# Altium schematic sheet Power-Electronics.SchDoc(Power-Electronics)
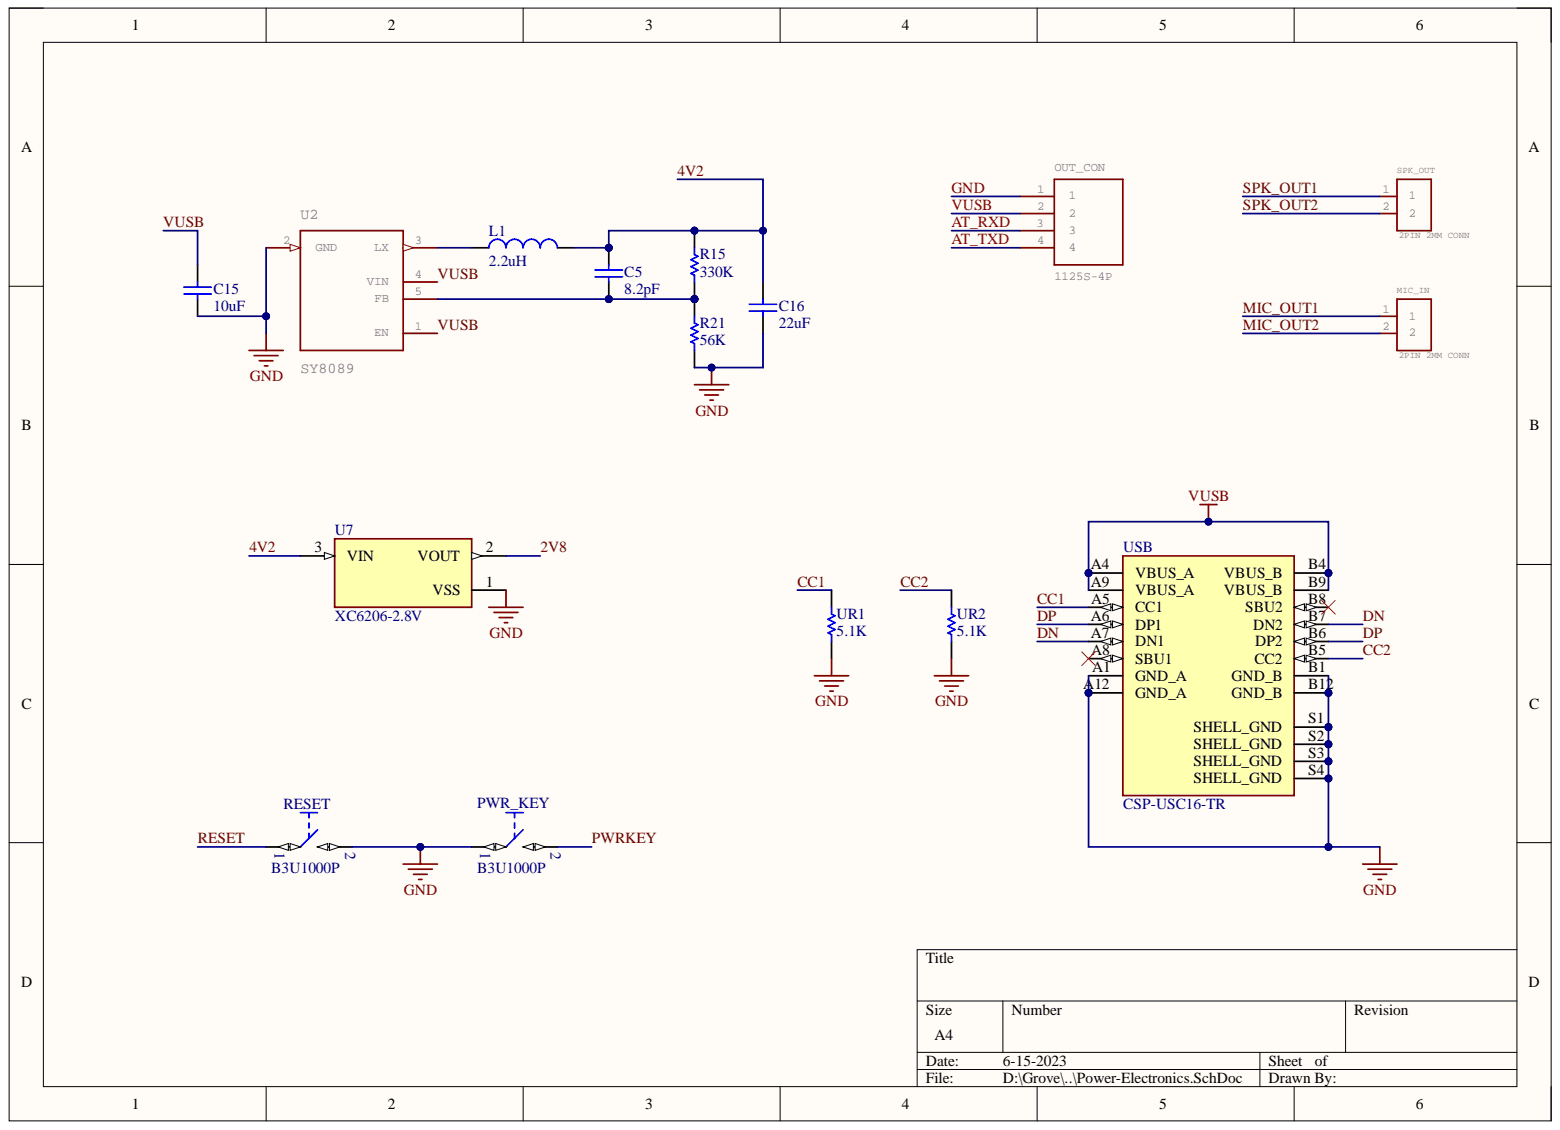
[diagram p0: Power-Electronics.SchDoc]

## Parts
C5  8.2pF  [CAPC3413X12N]
C15  10uF  [CAP805]
C16  22uF  [CAP805]
L1  2.2uH  [IND1206]
MIC_IN  2PIN 2MM CONN  [TE_292253-2]
OUT_CON  1125S-4P  [SEEED_110990030]
PWR_KEY  B3U1000P  [B3U-1000P]
R15  330K  [RESC3413X04N]
R21  56K  [RESC3413X04N]
RESET  B3U1000P  [B3U-1000P]
SPK_OUT  2PIN 2MM CONN  [TE_292253-2]
U2  SY8089  [SOT95P280X145-5N]
U7  XC6206-2.8V  [SOT95P280X130-3N]
UR1  5.1K  [RES0603]
UR2  5.1K  [RES0603]
USB  CSP-USC16-TR  [VALCON_CSP-USC16-TR]

## Nets
2V8: U7.2
4V2: C5.2 C16.2 L1.2 R15.2 U7.3
AT_RXD: OUT_CON.3
AT_TXD: OUT_CON.4
CC1: UR1.2 USB.A5(CC1)
CC2: UR2.2 USB.B5(CC2)
DN: USB.A7(DN1) USB.B7(DN2)
DP: USB.A6(DP1) USB.B6(DP2)
GND: C15.1 C16.1 OUT_CON.1 PWR_KEY.1 R21.1 RESET.2 U2.2(GND) U7.1 UR1.1 UR2.1 USB.A1(GND_A) USB.A12(GND_A) USB.B1(GND_B) USB.B12(GND_B) USB.S1(SHELL_GND) USB.S2(SHELL_GND) USB.S3(SHELL_GND) USB.S4(SHELL_GND)
MIC_OUT1: MIC_IN.1
MIC_OUT2: MIC_IN.2
PWRKEY: PWR_KEY.2
RESET: RESET.1
SPK_OUT1: SPK_OUT.1
SPK_OUT2: SPK_OUT.2
VUSB: C15.2 OUT_CON.2 U2.1(EN) U2.4(VIN) USB.A4(VBUS_A) USB.A9(VBUS_A) USB.B4(VBUS_B) USB.B9(VBUS_B)
NetC5_1: C5.1 R15.1 R21.2 U2.5(FB)
NetL1_1: L1.1 U2.3(LX)

Not connected: USB A8(SBU1) B8(SBU2)

## Library data of the parts
C5 = CAP SMD 0805: CAP 0805; Designer: Abbas Basereh; Component Kind: Standard; Data: 16-12-2016; Desc.: CAP SMD 0805; Library Name: Altium.IntLib
C15 C16 = CAP SMD PACKAGE: TOTAL CAPACITOR SMD PACK; Desc.: CAP SMD TOTAL PACKAGES; Designer: Abbas Basereh; VALUE: 100nF; Component Kind: Standard; Data: 2017-3-10; Library Name: Altium.IntLib; Signal Integrity: CAP SMD
L1 = INDUCTOR SMD PACKAGE: TOTAL INDUCTOR SMD PACK; Desc.: INDUCTOR  SMD TOTAL PACKAGES; Designer: Abbas Basereh; VALUE: 1u; Component Kind: Standard; Data: 2017-3-10; Library Name: Altium.IntLib; Signal Integrity: IND SMD
MIC_IN SPK_OUT = 292253-2: GateName_1: G$1; MF: TE Connectivity; Availability: In Stock; Component Kind: Standard; DeviceSetName: 292253-2; EU_RoHS_Compliance: Compliant; Library Name: 292253-2.Library.IntLib; LibraryName: 292253-2; MP: 292253-2; Package: TE_292253-2; Price: None; Purchase-URL: https://pricing.snapeda.com/search/part/292253-2/?ref=eda; SymbolName_1: 292253-2
OUT_CON = 110990030: GateName_1: G$1; MF: Seeed Technology Co., Ltd; Availability: In Stock; Component Kind: Standard; DeviceSetName: 110990030; Library Name: 110990030.Library.IntLib; LibraryName: 110990030; MP: 110990030; Package: SEEED_110990030; Price: None; Purchase-URL: https://pricing.snapeda.com/search/part/110990030/?ref=eda; SymbolName_1: 110990030
PWR_KEY RESET = B3U1000P: Switch Tactile N.O. SPST Round Button Gull Wing 0.05A 12VDC 1.5N SMD T/R; MF: Omron; Availability: In Stock; Check_prices: https://www.snapeda.com/parts/B3U1000P/Omron/view-part/?ref=eda; Component Kind: Standard; Library Name: B3U1000P.IntLib; MP: B3U1000P; Package: None; Price: None; SnapEDA_Link: https://www.snapeda.com/parts/B3U1000P/Omron/view-part/?ref=snap
R15 R21 = RES 0805 0.125W: RESISTOR 0805; Desc.: RES SMD 0805; Designer: Abbas Basereh; Component Kind: Standard; Data: 16-12-2016; Library Name: Altium.IntLib
U2 = TLV70433DBVR: GateName_1: G$1; MF: Texas Instruments; Availability: In Stock; Component Kind: Standard; DeviceSetName: TLV70433DBVR; Library Name: TLV70433DBVR.Library.IntLib; LibraryName: TLV70433DBVR; MP: TLV70433DBVR; Package: SOT95P280X145-5N; Price: None; Purchase-URL: https://pricing.snapeda.com/search/part/TLV70433DBVR/?ref=eda; SymbolName_1: TLV70433DBVR
U7 = XC6206P302MR: Linear Voltage Regulator IC 1 Output 200mA SOT-23; Availability: Unavailable; Component Kind: Standard; Library Name: XC6206P302MR.IntLib; MP: XC6206P302MR; Package: None; Price: None
UR1 UR2 = RES SMD PACKAGE: RESISTOR 0603; Desc.: RES SMD PAKAGES; Designer: Abbas Basereh; Component Kind: Standard; Data: 2017-3-10; Library Name: Altium.IntLib
USB = CSP-USC16-TR: CSP-USC16-TR Valcon USB Type C Surface Mount PCB Socket; Availability: In Stock; Check_prices: https://www.snapeda.com/parts/CSP-USC16-TR/Valcon/view-part/?ref=eda; Component Kind: Standard; Library Name: CSP-USC16-TR.IntLib; MF: Valcon; MP: CSP-USC16-TR; Package: None; Price: None; Purchase-URL: https://pricing.snapeda.com/search/part/CSP-USC16-TR/?ref=eda; SnapEDA_Link: https://www.snapeda.com/parts/CSP-USC16-TR/Valcon/view-part/?ref=snap
